# Altium schematic sheet 04-MCU.SchDoc
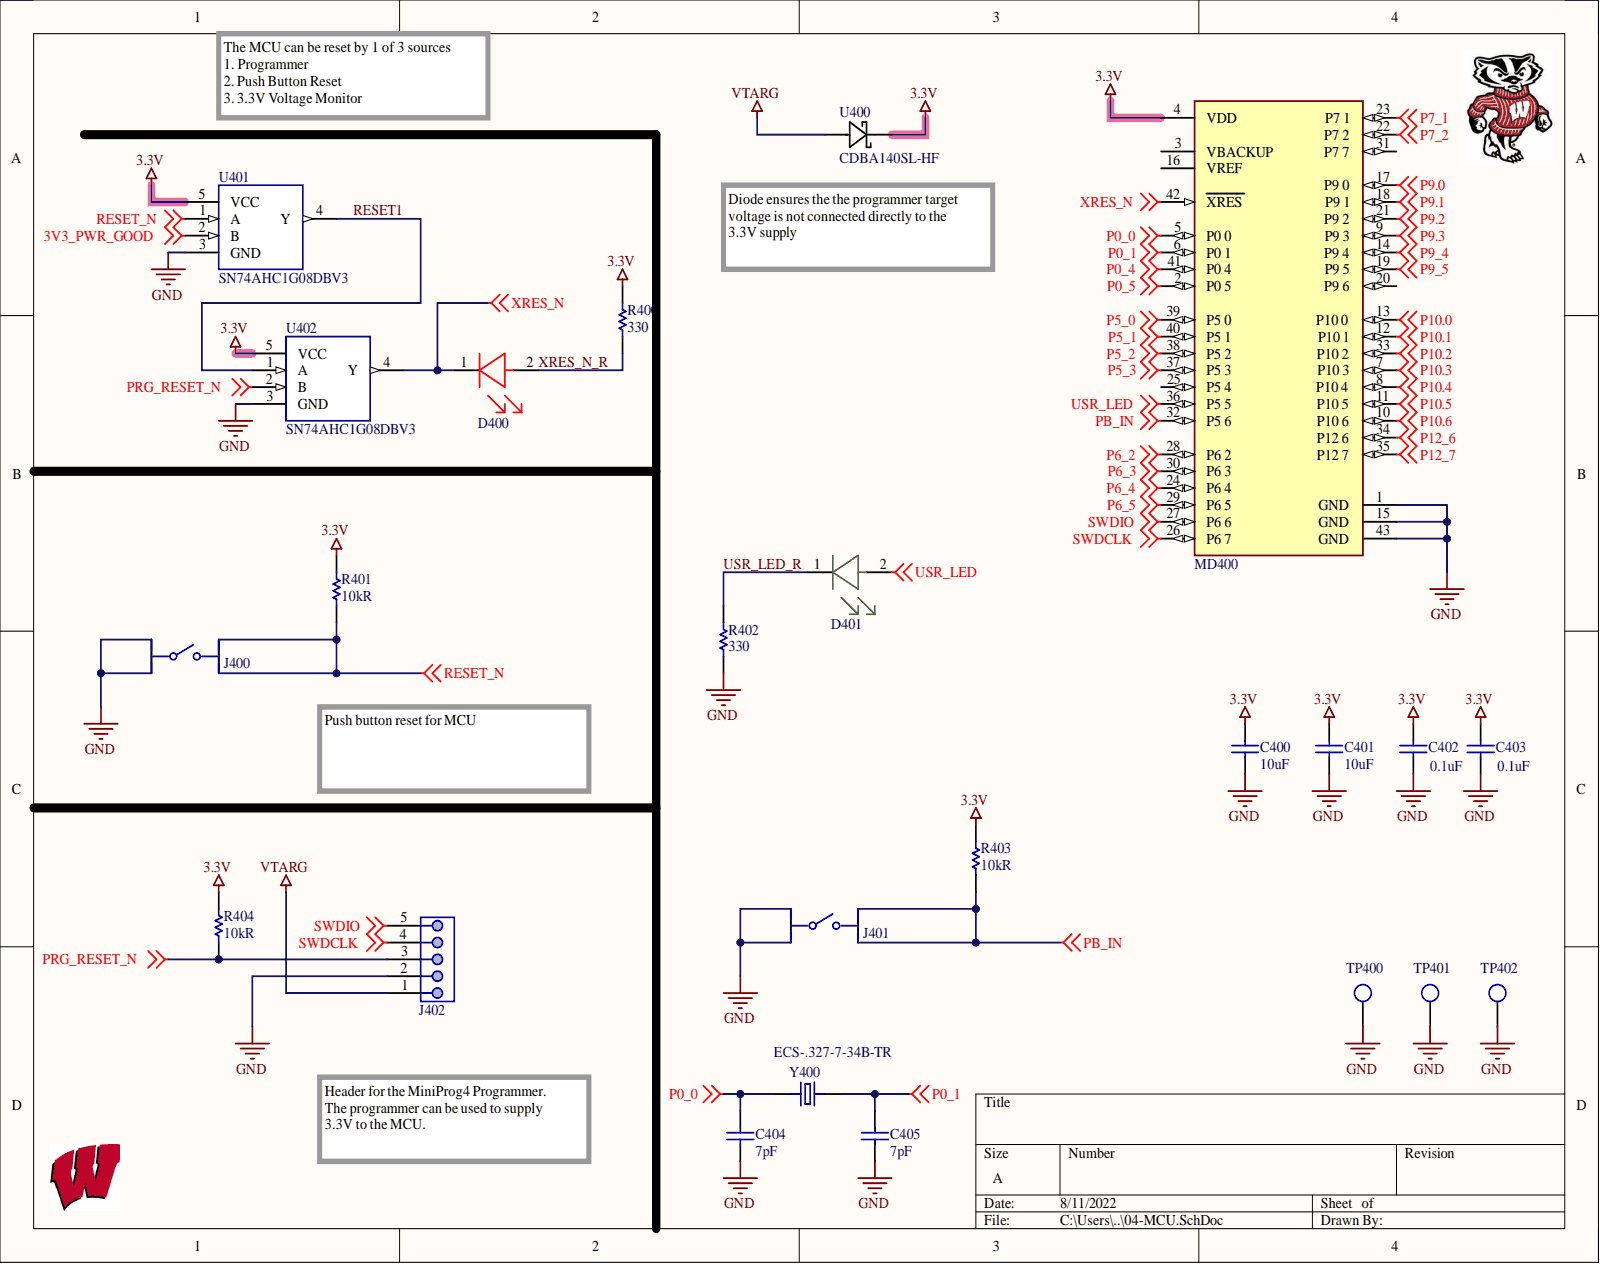
[diagram p0: 04-MCU.SchDoc]

## Parts
C400  10uF  [CAP_0805]
C401  10uF  [CAP_0805]
C402  0.1uF  [CAP_0805]
C403  0.1uF  [CAP_0805]
C404  Capacitance: 7pF  [CAP_0805]  (hidden comment: CAP_0805_P_007.00)
C405  Capacitance: 7pF  [CAP_0805]  (hidden comment: CAP_0805_P_007.00)
D400  LED_RED_0805.1  [LED_RED_0805]  (value not drawn)
D401  LED_GREEN_0805.1  [LED_GRN_0805]  (value not drawn)
J400  SPST-NO  [TE_CONNCECTIVITY_1977223-6_0]  (value not drawn)
J401  SPST-NO  [TE_CONNCECTIVITY_1977223-6_0]  (value not drawn)
J402  CONN_HEADER_MALE_1x5  [HDR1X5]  (value not drawn)
MD400  CYBLE-416045-02  [Cypress_Semiconductor_0_MFG]  (value not drawn)
R400  330  [RES_0805]
R401  Resistance: 10kR  [RES_0805]  (hidden comment: RES_0805_K_010.00)
R402  330  [RES_0805]
R403  Resistance: 10kR  [RES_0805]  (hidden comment: RES_0805_K_010.00)
R404  Resistance: 10kR  [RES_0805]  (hidden comment: RES_0805_K_010.00)
TP400  TP_1206  [TP-SMC-1206]  (value not drawn)
TP401  TP_1206  [TP-SMC-1206]  (value not drawn)
TP402  TP_1206  [TP-SMC-1206]  (value not drawn)
U400  CDBA140SL-HF  [CDBA-HF]
U401  SN74AHC1G08DBV3  [SOT23-5-AND]
U402  SN74AHC1G08DBV3  [SOT23-5-AND]
Y400  ECS-.327-7-34B-TR  [XTAL_ECS-.327-7-34B-TR]

## Nets
3.3V: C400.2 C401.2 C402.2 C403.2 MD400.4(VDD) R400.2 R401.2 R403.2 R404.2 U400.1 U401.5(VCC) U402.5(VCC)
3V3_PWR_GOOD: U401.2(B)
GND: C400.1 C401.1 C402.1 C403.1 C404.1 C405.1 J400.1 J400.2 J401.1 J401.2 J402.2 MD400.1(GND) MD400.15(GND) MD400.43(GND) R402.1 TP400.1 TP401.1 TP402.1 U401.3(GND) U402.3(GND)
P0_0: C404.2 MD400.5(P0_0) Y400.1
P0_1: C405.2 MD400.6(P0_1) Y400.2
P0_4: MD400.41(P0_4)
P0_5: MD400.2(P0_5)
P5_0: MD400.39(P5_0)
P5_1: MD400.40(P5_1)
P5_2: MD400.38(P5_2)
P5_3: MD400.37(P5_3)
P6_2: MD400.28(P6_2)
P6_3: MD400.30(P6_3)
P6_4: MD400.24(P6_4)
P6_5: MD400.29(P6_5)
P7_1: MD400.23(P7_1)
P7_2: MD400.22(P7_2)
P9.0: MD400.14(P9_4) MD400.17(P9_0) MD400.19(P9_5)
P9.1: MD400.18(P9_1)
P9.2: MD400.21(P9_2)
P9.3: MD400.9(P9_3)
P10.0: MD400.13(P10_0)
P10.1: MD400.12(P10_1)
P10.2: MD400.33(P10_2)
P10.3: MD400.7(P10_3)
P10.4: MD400.8(P10_4)
P10.5: MD400.11(P10_5)
P10.6: MD400.10(P10_6)
P12_6: MD400.34(P12_6)
P12_7: MD400.35(P12_7)
PB_IN: J401.3 J401.4 MD400.32(P5_6) R403.1
PRG_RESET_N: J402.3 R404.1 U402.2(B)
RESET_N: J400.3 J400.4 R401.1 U401.1(A)
SWDCLK: J402.4 MD400.26(P6_7)
SWDIO: J402.5 MD400.27(P6_6)
USR_LED: D401.2 MD400.36(P5_5)
VTARG: J402.1 U400.2
XRES_N: D400.1 MD400.42(XRES) U402.4(Y)
RESET1: U401.4(Y) U402.1(A)
USR_LED_R: D401.1 R402.2
XRES_N_R: D400.2 R400.1

Not connected: MD400 3(VBACKUP) 16(VREF) 20(P9_6) 25(P5_4) 31(P7_7)

## Library data of the parts
C400 C401 = CAP_0805_U_010.00: CAP CER 10UF 16V X7R 0805; Manufacturer: Samsung; Manufacturer Part Number: CL21A106KPCLQNC; Dielectric: X5R; Tolerance: 10%; Voltage Rating: 10V; Capacitance: 10uF; Case Code (Imperial): 0805; Case Code (Metric): 2012; Case/Package: 0805; Component Kind: Standard; ComponentLink1Description: https://datasheet.ciiva.com/25730/cl21a106kpclqnc-25730594.pdf; ComponentLink1URL: https://datasheet.ciiva.com/25730/cl21a106kpclqnc-25730594.pdf; ComponentLink3Description: https://datasheet.ciiva.com/26920/getdatasheetpartid-355170-26920201.pdf; ComponentLink3URL: https://datasheet.ciiva.com/26920/getdatasheetpartid-355170-26920201.pdf; Contact Plating: Tin; Depth: 1.25mm; Height: 0.85mm; Length: 2mm; Library Name: Cap_Ceramic.SchLib; Max Operating Temperature: 85°C; Min Operating Temperature: -55°C; Mount: Surface Mount; Package Quantity: 1; Packaging: Tape and Reel; Pricing 1: 1=0.22, 10=0.148, 100=0.0749, 500=0.05122, 1000=0.04531 (USD); Radiation Hardening: No; Stock 1: 40000; Supplier: Digi-Key; Supplier Part Number: 1276-2402-1-ND; Thickness: 0.037inch; Width: 0.049inch
C402 C403 = CAP_0805_U_000.10: CAP CER 0.1UF 16V 10% X7R 0805; Manufacturer 1: KEMET; Manufacturer Part Number 1: C0805C104K4RACTU; Capacitance: 100nF; Dielectric Material: Ceramic; Case Code (Imperial): 0805; Case Code (Metric): 2012; Case/Package: 0805; Component Kind: Standard; ComponentLink1Description: http://components.arrow.com/part/dataview/0323_00070420; ComponentLink1URL: http://components.arrow.com/part/dataview/0323_00070420; ComponentLink2Description: http://uk.rs-online.com/webdocs/1515/0900766b815151c5.pdf; ComponentLink2URL: http://uk.rs-online.com/webdocs/1515/0900766b815151c5.pdf; ComponentLink3Description: http://capacitoredge.kemet.com/capedge2/DataSheet?pn=C0805C104K4RACTU; ComponentLink3URL: http://capacitoredge.kemet.com/capedge2/DataSheet?pn=C0805C104K4RACTU; ComponentLink4Description: http://docs-usa.electrocomponents.com/techlib:/itc_doclist_US.htm?stockno=6480957; ComponentLink4URL: http://docs-usa.electrocomponents.com/techlib:/itc_doclist_US.htm?stockno=6480957; ComponentLink5Description: https://datasheet.ciiva.com/46443/1851736-46443710.pdf?src-supplier=Element14; ComponentLink5URL: https://datasheet.ciiva.com/46443/1851736-46443710.pdf?src-supplier=Element14; ComponentLink6Description: https://datasheet.ciiva.com/46443/1851736-46443711.pdf?src-supplier=Element14; ComponentLink6URL: https://datasheet.ciiva.com/46443/1851736-46443711.pdf?src-supplier=Element14; Contact Plating: Tin,Matte; Depth: 1.25mm; Dielectric: X7R; Height: 0.9mm; Lead Pitch: 0.75mm; Length: 2mm; Library Name: Cap_Ceramic.SchLib; Max Operating Temperature: 125°C; Min Operating Temperature: -55°C; Mount: Surface Mount,PCB; Number of Pins: 2; Package Quantity: 1; Packaging: Tape and Reel; Pricing 1: 1=0.20000, 10=0.13900, 100=0.07020, 500=0.04802, 1000=0.04248 (USD); Radiation Hardening: No; REACH SVHC: No SVHC; RoHS: Yes; Stock 1: 336262; Supplier 1: Digi-Key; Supplier Part Number 1: 399-1167-1-ND; Termination: SMD/SMT; Thickness: 0.035inch; Tolerance: 10%; Voltage: 16V; Voltage Rating: 16V; Voltage Rating (DC): 16V; Width: 0.049inch
C404 C405 = CAP_0805_P_007.00: 0805 7 pF 50 V ±0.25 pF Tolerance C0G/NP0 SMT Multilayer Ceramic Capacitor; Manufacturer 1: Kyocera AVX; Manufacturer Part Number 1: 08055A7R0CAT2A; Dielectric: C0G; Voltage Rating: 50V; Case Code (Imperial): 0805; Case Code (Metric): 2012; Case/Package: 0805; Category: Ceramic Capacitors; Component Kind: Standard; ComponentLink1Description: https://datasheet.ciiva.com/204/ccog-204944.pdf; ComponentLink1URL: https://datasheet.ciiva.com/204/ccog-204944.pdf; ComponentLink2Description: https://datasheet.ciiva.com/11570/ccog-11570969.pdf; ComponentLink2URL: https://datasheet.ciiva.com/11570/ccog-11570969.pdf; ComponentLink3Description: https://datasheet.ciiva.com/205/ccog-205398.pdf?src-supplier=Digi-Key; ComponentLink3URL: https://datasheet.ciiva.com/205/ccog-205398.pdf?src-supplier=Digi-Key; Construction: Flat; Depth: 1.25mm; Lead Free: Lead Free; Length: 2.01mm; Library Name: Cap_Ceramic.SchLib; Max Operating Temperature: 125°C; Min Operating Temperature: -55°C; Mount: Surface Mount; Package Quantity: 4000; Packaging: Tape and Reel; Pricing 1: 1=0.19000, 10=0.13500, 50=0.07680, 100=0.06360, 500=0.04462, 1000=0.03694 (USD); Radiation Hardening: No; RoHS: Yes; Series: -; Stock 1: 19240; Supplier 1: Digi-Key; Supplier Part Number 1: 478-10543-6-ND; Termination: SMD/SMT; Thickness: 0.037inch; Voltage Rating (DC): 50V; Weight: 0.000194oz; Width: 1.25mm
D400 = LED_RED_0805.1: LED SUPER RED CLR THIN 0805 SMD; Manufacturer: Vishay / Lite-On; Manufacturer Part Number: LTST-C171KRKT; Chip Material: AlGaInP; DELETED: Compliant; Dominant Wave Length: 631 nm; Forward Current: 30 mA; Forward Voltage: 2.4 V; High Brightness: Yes; Lum Intensity: 54mcd; Main Category: Chip LED; Number of  Elements: 1; Peak Wavelength: 639 nm; Power Dissipation: 75 W; Product Depth (mm): 1.25 mm; Product Height (mm): 0.8 mm; Product Length (mm): 2 mm; Rad Hardened: No; Reverse Voltage: 5 V; Shape Type: Rectangular; Test Current (It): 20 mA; Type: Uni-Color; Viewing Angle: 130 deg; Component Kind: Standard; ComponentLink1Description: https://datasheet.ciiva.com/2110/s-110-ltst-c171krkt-2110066.pdf; ComponentLink1URL: https://datasheet.ciiva.com/2110/s-110-ltst-c171krkt-2110066.pdf; ComponentLink2Description: https://datasheet.ciiva.com/2110/s-110-ltst-c171krkt-2110067.pdf; ComponentLink2URL: https://datasheet.ciiva.com/2110/s-110-ltst-c171krkt-2110067.pdf; ComponentLink3Description: https://datasheet.ciiva.com/2110/s-110-ltst-c171krkt-2110068.pdf; ComponentLink3URL: https://datasheet.ciiva.com/2110/s-110-ltst-c171krkt-2110068.pdf; ComponentLink4Description: https://datasheet.ciiva.com/12403/lite-on-ltst-c171krkt-187201-12403781.pdf; ComponentLink4URL: https://datasheet.ciiva.com/12403/lite-on-ltst-c171krkt-187201-12403781.pdf; ComponentLink5Description: https://datasheet.ciiva.com/11775/0900766b80dc0299-11775643.pdf; ComponentLink5URL: https://datasheet.ciiva.com/11775/0900766b80dc0299-11775643.pdf; ComponentLink6Description: https://datasheet.ciiva.com/16444/lite-on20ltst-c171krkt-16444779.pdf; ComponentLink6URL: https://datasheet.ciiva.com/16444/lite-on20ltst-c171krkt-16444779.pdf; Emitting Color: Red; Lens Dimensions: 1.4x1.25x0.5 mm; Library Name: LEDs.SchLib; Luminous Intensity: 54mcd; Mounting: Surface Mount; Operating Temp Range: -55C to 85C; Operating Temperature Classification: Industrial; Package Type: Chip Led; Packaging: Tape and Reel; Pin Count: 2; Pricing 1: 1=0.31, 10=0.225, 25=0.1656, 100=0.1183, 250=0.0804, 500=0.071, 1000=0.0556 (USD); Stock 1: 97347; Supplier 1: Digikey; Supplier Part Number 1: 160-1427-1-ND
D401 = LED_GREEN_0805.1: LED GREEN CLEAR THIN 0805 SMD; Manufacturer 1: Vishay / Lite-On; Manufacturer Part Number 1: LTST-C171KGKT; Chip Material: AlGaInP; DELETED: Compliant; Dominant Wave Length: 571 nm; Emitting Color: Yellow Green; Forward Current: 30 mA; Forward Voltage: 2.4 V; High Brightness: Yes; Lum Intensity: 35mcd; Main Category: Chip LED; Number of  Elements: 1; Peak Wavelength: 574 nm; Power Dissipation: 75 W; Product Depth (mm): 1.25 mm; Product Height (mm): 0.8 mm; Product Length (mm): 2 mm; Rad Hardened: No; Reverse Voltage: 5 V; Shape Type: Rectangular; Test Current (It): 20 mA; Type: Uni-Color; Viewing Angle: 130 deg; Component Kind: Standard; ComponentLink1Description: https://datasheet.ciiva.com/15118/getdatasheetpartid-214542-15118099.pdf; ComponentLink1URL: https://datasheet.ciiva.com/15118/getdatasheetpartid-214542-15118099.pdf; ComponentLink2Description: https://datasheet.ciiva.com/16200/lite-on20ltst-c171kgkt-16200100.pdf; ComponentLink2URL: https://datasheet.ciiva.com/16200/lite-on20ltst-c171kgkt-16200100.pdf; ComponentLink3Description: https://datasheet.ciiva.com/2110/s-110-ltst-c171kgkt-2110072.pdf; ComponentLink3URL: https://datasheet.ciiva.com/2110/s-110-ltst-c171kgkt-2110072.pdf; ComponentLink4Description: https://datasheet.ciiva.com/2110/s-110-ltst-c171kgkt-2110073.pdf; ComponentLink4URL: https://datasheet.ciiva.com/2110/s-110-ltst-c171kgkt-2110073.pdf; ComponentLink5Description: https://datasheet.ciiva.com/11774/0900766b80dc0298-11774377.pdf; ComponentLink5URL: https://datasheet.ciiva.com/11774/0900766b80dc0298-11774377.pdf; ComponentLink6Description: https://datasheet.ciiva.com/2110/s-110-ltst-c171kgkt-2110074.pdf; ComponentLink6URL: https://datasheet.ciiva.com/2110/s-110-ltst-c171kgkt-2110074.pdf; ComponentLink7Description: https://datasheet.ciiva.com/12400/lite-on-ltst-c171kgkt-187692-12400799.pdf; ComponentLink7URL: https://datasheet.ciiva.com/12400/lite-on-ltst-c171kgkt-187692-12400799.pdf; Lens Dimensions: 1.4x1.25x0.5 mm; Library Name: LEDs.SchLib; Luminous Intensity: 35mcd; Mounting: Surface Mount; Operating Temp Range: -55C to 85C; Operating Temperature Classification: Industrial; Package Type: Chip Led; Packaging: Tape and Reel; Pin Count: 2; Pricing 1: 1=0.31, 10=0.225, 25=0.1656, 100=0.1183, 250=0.0804, 500=0.071, 1000=0.0556 (USD); Stock 1: 322411; Supplier 1: Digi-Key; Supplier Part Number 1: 160-1426-1-ND
J400 J401 = SPST-NO: CONN SWITCH TACTILE SPST-NO 0.05A 24V; Manufacturer: TE CONNCECTIVITY; Part Number: 1977223-6; Component Kind: Standard; ComponentLink1Description: Upverter; ComponentLink1URL: https://upverter.com/upn/revision/93322581e4cbca7c/; ComponentLink2Description: Datasheet; ComponentLink2URL: http://www.mouser.com/ds/2/418/NG_CD_1977223_A4-679782.pdf; Digikey Description: CONN SWITCH TACTILE SPST-NO 0.05A 24V; Digikey Part Number: 450-2054-1-ND; helpURL: https://upverter.com/upn/revision/93322581e4cbca7c/; Lead Free: yes; Library Name: Switches.SchLib; Mouser Part Number: 506-1977223-6; Package: SW_TACT; RoHS: TRUE; Supplier 1: Digi-Key; Supplier 2: Mouser; Supplier Part Number 1: 450-2054-1-ND; Supplier Part Number 2: 506-1977223-6; Temperature: -40°C to +105°C; Temperature Range High: +105°C; Temperature Range Low: -40°C
J402 = CONN_HEADER_MALE_1x5: Header, 5-Pin; Manufacturer 1: Sullins; Manufacturer Part Number 1: PREC005SACN-RC; Connector Type: Male Pin; Number of Positions: 5; Pitch: 0.1inch; Color: Black; Component Kind: Standard; ComponentLink1Description: http://www.farnell.com/cad/1737268.pdf; ComponentLink1URL: http://www.farnell.com/cad/1737268.pdf; ComponentLink2Description: http://katalog.we-online.de/em/datasheet/6130xx11121.pdf; ComponentLink2URL: http://katalog.we-online.de/em/datasheet/6130xx11121.pdf; ComponentLink3Description: https://datasheet.ciiva.com/741/xrxczzzsxxn-rc-st-11635-b-741840.pdf?src-supplier=Digi-Key; ComponentLink3URL: https://datasheet.ciiva.com/741/xrxczzzsxxn-rc-st-11635-b-741840.pdf?src-supplier=Digi-Key; Contact Mating Length: 0.23inch; Contact Plating: Tin; Library Name: Connectors.SchLib; Mount: Through Hole; Number of Rows: 1; Package Quantity: 1; Packaging: Bulk; Pricing 1: 1=0.19000, 10=0.17400, 25=0.12960, 50=0.11120, 100=0.10740, 250=0.09264, 500=0.08892 (USD); RoHS: Yes; Stock 1: 0; Supplier 1: Digi-Key; Supplier Part Number 1: S1032EC-05-ND; Termination: Solder
MD400 = CYBLE-416045-02: Bluetooth v5.0 Transceiver Module 2.4GHz ~ 2.5GHz Integrated, Trace Surface Mount; Component Kind: Standard; Library Name: ICs.SchLib; Supplier 1: Digi-Key; Supplier Part Number 1: 428-4622-1-ND
R400 R402 = RES_0805_R_330.00: RES 330 OHM 1% 1/8W 0805; Manufacturer 1: Stackpole Electronics; Manufacturer Part Number 1: RMCF0805FT330R; Max Power Dissipation: 125mW; Resistance: 330R; Temperature Coefficient: 100ppm/°C; Case Code (Imperial): 0805; Case Code (Metric): 2012; Case/Package: 0805; Category: Chip SMD Resistors; Component Kind: Standard; ComponentLink1Description: http://components.arrow.com/part/dataview/40963487S9848862N1174?src-supplier=Arrow+Electronics; ComponentLink1URL: http://components.arrow.com/part/dataview/40963487S9848862N1174?src-supplier=Arrow+Electronics; ComponentLink2Description: https://www.seielect.com/catalog/SEI-RMCF_RMCP.pdf?src-supplier=Avnet; ComponentLink2URL: https://www.seielect.com/catalog/SEI-RMCF_RMCP.pdf?src-supplier=Avnet; ComponentLink3Description: https://www.seielect.com/Catalog/SEI-RMCF_RMCP.pdf?src-supplier=Digi-Key; ComponentLink3URL: https://www.seielect.com/Catalog/SEI-RMCF_RMCP.pdf?src-supplier=Digi-Key; ComponentLink4Description: https://www.seielect.com/catalog/SEI-Packaging.pdf?src-supplier=Digi-Key; ComponentLink4URL: https://www.seielect.com/catalog/SEI-Packaging.pdf?src-supplier=Digi-Key; ComponentLink5Description: https://www.verical.com/server-webapp/html/getDatasheet?partID=559884&src-supplier=Verical; ComponentLink5URL: https://www.verical.com/server-webapp/html/getDatasheet?partID=559884&src-supplier=Verical; Construction: Rectangular; Contact Plating: Tin,Matte; Depth: 1.25mm; Features: Automotive AEC-Q200; Height: 0.026inch; Lead Free: Lead Free; Length: 0.079inch; Library Name: Resistors.SchLib; Max Operating Temperature: 155°C; Military Standard: Not; Min Operating Temperature: -55°C; Mount: Surface Mount; Number of Terminations: 2; Packaging: Tape and Reel; Pricing 1: 1=0.10000, 10=0.02700, 100=0.01090, 1000=0.00488, 2500=0.00423 (USD); Radiation Hardening: No; Resistor Type: Thick Film; RoHS: Yes; RoHS Compliant: Yes; Series: RMCF; Stock 1: 205996; Supplier 1: Digi-Key; Supplier Part Number 1: RMCF0805FT330RCT-ND; Tolerance: 1%; Width: 0.049inch
R401 R403 R404 = RES_0805_K_010.00: RES SMD 10K OHM 1% 1/8W 0805; Manufacturer 1: Yageo; Manufacturer Part Number 1: RC0805FR-0710KL; Max Power Dissipation: 125mW; Temperature Coefficient: 100ppm/°C; Voltage Rating: 150V; Case Code (Imperial): 0805; Case Code (Metric): 2012; Case/Package: 0805; Category: Chip SMD Resistors; Component Kind: Standard; ComponentLink1Description: https://datasheet.ciiva.com/11808/0900766b8106beab-11808151.pdf?src-supplier=RSComponents; ComponentLink1URL: https://datasheet.ciiva.com/11808/0900766b8106beab-11808151.pdf?src-supplier=RSComponents; ComponentLink2Description: https://datasheet.ciiva.com/12669/rc0805-12669688.pdf?src-supplier=Mouser; ComponentLink2URL: https://datasheet.ciiva.com/12669/rc0805-12669688.pdf?src-supplier=Mouser; ComponentLink3Description: https://datasheet.ciiva.com/46447/444164-46447563.pdf?src-supplier=Element14; ComponentLink3URL: https://datasheet.ciiva.com/46447/444164-46447563.pdf?src-supplier=Element14; ComponentLink4Description: https://datasheet.ciiva.com/2456/pyu-r-int-thick-7-2456972.pdf?src-supplier=Digi-Key; ComponentLink4URL: https://datasheet.ciiva.com/2456/pyu-r-int-thick-7-2456972.pdf?src-supplier=Digi-Key; ComponentLink5Description: https://datasheet.ciiva.com/2456/pyu-r-int-thick-7-2456973.pdf?src-supplier=Digi-Key; ComponentLink5URL: https://datasheet.ciiva.com/2456/pyu-r-int-thick-7-2456973.pdf?src-supplier=Digi-Key; ComponentLink6Description: https://datasheet.ciiva.com/2456/pyu-r-int-thick-7-2456974.pdf?src-supplier=Digi-Key; ComponentLink6URL: https://datasheet.ciiva.com/2456/pyu-r-int-thick-7-2456974.pdf?src-supplier=Digi-Key; ComponentLink7Description: https://datasheet.ciiva.com/16747/rc0805-16747087.pdf?src-supplier=Future+Electronics; ComponentLink7URL: https://datasheet.ciiva.com/16747/rc0805-16747087.pdf?src-supplier=Future+Electronics; Construction: Rectangular; Depth: 1.25mm; Features: Moisture Resistant; Height: 0.024inch; Lead Free: Lead Free; Length: 2mm; Library Name: Resistors.SchLib; Max Operating Temperature: 155°C; Military Standard: Not; Min Operating Temperature: -55°C; Number of Terminations: 2; Package Quantity: 1; Packaging: Tape and Reel; Power Rating: 125mW; Pricing 1: 1=0.10000, 10=0.04200, 100=0.01690, 1000=0.00759, 2500=0.00659 (USD); REACH SVHC: Unknown; Resistance Tolerance: 1%; Resistor Type: Thick Film; RoHS: Yes; RoHS Compliant: Yes; Series: RC; Size Code: 0805; Stock 1: 7562122; Supplier 1: Digi-Key; Supplier Part Number 1: 311-10.0KCRCT-ND; Technology: THICK FILM; Termination: SMD/SMT; Tolerance: 1%; Width: 0.049inch
TP400-TP402 = TP_1206: Test Point SMD 1206; Manufacturer: TE Connectivity (Tyco Electronics); Manufacturer Part Number: 1625854-1; Power Rating: N/R; Resistance Value: 0 Ohm; Case Size: 1206; Component Kind: Standard; ComponentLink1Description: https://datasheet.ciiva.com/6439/eng-ds-1773266-a-6439169.pdf; ComponentLink1URL: https://datasheet.ciiva.com/6439/eng-ds-1773266-a-6439169.pdf; ComponentLink2Description: https://datasheet.ciiva.com/30136/1773266-a-30136341.pdf; ComponentLink2URL: https://datasheet.ciiva.com/30136/1773266-a-30136341.pdf; ComponentLink3Description: https://datasheet.ciiva.com/6439/eng-ds-1773266-a-6439080.pdf; ComponentLink3URL: https://datasheet.ciiva.com/6439/eng-ds-1773266-a-6439080.pdf; ComponentLink4Description: https://datasheet.ciiva.com/6439/eng-ds-1773266-a-6439081.pdf; ComponentLink4URL: https://datasheet.ciiva.com/6439/eng-ds-1773266-a-6439081.pdf; ComponentLink5Description: https://datasheet.ciiva.com/6439/eng-ds-1773266-a-6439083.pdf; ComponentLink5URL: https://datasheet.ciiva.com/6439/eng-ds-1773266-a-6439083.pdf; Dimension: 3.2 x 1.6 x 1.25mm; Family: RC; Library Name: Mechanical.SchLib; Mounting: Surface Mount; Operating Temperature: -55 to 125 C; Packaging: Tape and Reel; Part No: 1625854-1; Pricing 1: 1=0.28, 10=0.261, 25=0.224, 50=0.1902, 100=0.1828, 250=0.1641, 500=0.1567, 1000=0.1306 (USD); RoHS: Yes; Stock 1: 31937; Supplier: Digi-Key; Supplier Part Number: A106143CT-ND; Temperature Coefficient: N/R; Terminal Pitch: N/R; Termination Style: N/R; Tolerance: N/R
U400 = CDBA140SL-HF: Rectifier Diode Schottky 40V 1A 2-Pin SMA T/R; Manufacturer 1: Comchip; Average Rectified Current: 1.4A; Max Surge Current: 30A; Case/Package: DO-214AC; Category: Rectifier Diodes; Component Kind: Standard; ComponentLink1Description: https://datasheet.ciiva.com/6377/cdba120sl-hfthru295959-cdba140sl-hfreva-6377798.pdf?src-supplier=Digi-Key; ComponentLink1URL: https://datasheet.ciiva.com/6377/cdba120sl-hfthru295959-cdba140sl-hfreva-6377798.pdf?src-supplier=Digi-Key; Digi-Key_Part_Number_1: 641-1762-1-ND; Digi-Key_Part_Number_2: 641-1762-2-ND; Digi-Key_Part_Number_3: 641-1762-2-NDL; Digi-Key_Part_Number_4: 641-1762-6-ND; Digi-Key_Part_Number_5: CDBA140SL-HF-ND; Library Name: Diodes.SchLib; Manufacturer Part Number 1: CDBA140SL-HF; Manufacturer_Part_Number: CDBA140SL-HF; Max Reverse Voltage (DC): 40V; Mfr_Name: Comchip; Mount: Surface Mount; Package Quantity: 5000; Packaging: Tape and Reel; Pricing 1: 1=0.60000, 10=0.52400, 100=0.40140, 500=0.31734, 1000=0.25387, 2000=0.23007 (USD); RefDes: RefDes; RoHS: Yes; RoHS Compliant: Yes; Series: -; Stock 1: 277936; Supplier 1: Digi-Key; Supplier Part Number 1: 641-1762-1-ND; Type: DEV; Copyright: Copyright (C) 2022 Ultra Librarian. All rights reserved.
U401 U402 = SN74AHC1G08DBV3: AND Gate 1-Element 2-IN CMOS 5-Pin SOT-23 T/R; Manufacturer 1: Texas Instruments; Manufacturer Part Number 1: SN74AUP1G08DBVR; High Level Output Current: -4mA; Input Type: SCHMITT TRIGGER; Low Level Output Current: 4mA; Max Supply Voltage: 3.6V; Min Supply Voltage: 800mV; Number of Bits: 1; Number of Input Lines: 2; Propagation Delay: 6.2ns; Quiescent Current: 500nA; Turn-On Delay Time: 20.9ns; Case/Package: SOT-23; Category: Logic Gates; Component Kind: Standard; ComponentLink1Description: https://datasheet.ciiva.com/7122/1857511-7122245.pdf?src-supplier=Newark; ComponentLink1URL: https://datasheet.ciiva.com/7122/1857511-7122245.pdf?src-supplier=Newark; ComponentLink2Description: https://datasheet.ciiva.com/1864/sn74aup1g08-1864690.pdf?src-supplier=Digi-Key; ComponentLink2URL: https://datasheet.ciiva.com/1864/sn74aup1g08-1864690.pdf?src-supplier=Digi-Key; ComponentLink3Description: https://datasheet.ciiva.com/1864/sn74aup1g08-1864689.pdf?src-supplier=Digi-Key; ComponentLink3URL: https://datasheet.ciiva.com/1864/sn74aup1g08-1864689.pdf?src-supplier=Digi-Key; ComponentLink4Description: https://datasheet.ciiva.com/1864/sn74aup1g08-1864691.pdf?src-supplier=Digi-Key; ComponentLink4URL: https://datasheet.ciiva.com/1864/sn74aup1g08-1864691.pdf?src-supplier=Digi-Key; ComponentLink5Description: https://datasheet.ciiva.com/14291/sn74aup1g08-14291291.pdf?src-supplier=Avnet; ComponentLink5URL: https://datasheet.ciiva.com/14291/sn74aup1g08-14291291.pdf?src-supplier=Avnet; ComponentLink6Description: https://datasheet.ciiva.com/14291/sn74aup1g08-14291295.pdf?src-supplier=Avnet; ComponentLink6URL: https://datasheet.ciiva.com/14291/sn74aup1g08-14291295.pdf?src-supplier=Avnet; Contact Plating: Tin,Matte; Lead Free: Lead Free; Library Name: ICs.SchLib; Logic Function: AND GATE; Max Operating Temperature: 85°C; Min Operating Temperature: -40°C; Mount: Surface Mount; Number of Circuits: 1; Number of Elements: 1; Number of Gates: 1; Number of Inputs: 2; Number of Output Lines: 1; Number of Outputs: 1; Number of Pins: 5; Output Current: 4mA; Package Quantity: 3000; Packaging: Tape and Reel; Pricing 1: 1=0.49000, 10=0.39400, 25=0.36000, 100=0.26800, 250=0.24284, 500=0.20098, 1000=0.15073 (USD); Radiation Hardening: No; REACH SVHC: No SVHC; RoHS: Yes; RoHS Compliant: Yes; Series: 74AUP; Stock 1: 21212; Supplier 1: Digi-Key; Supplier Part Number 1: 296-SN74AUP1G08DBVRCT-ND; Technology: CMOS; Weight: 0.000395oz
Y400 = ECS-.327-7-34B-TR: Crystal 0.032768MHz ±20ppm (Tol) 7pF Flexural 70000Ohm 2-Pin Ultra Mini-CSMD T/R; Manufacturer 1: ECS International; ESR (Equivalent Series Resistance): 70kR; Frequency: 32.768kHz; MF: ECS Inc.; Availability: Unavailable; Case/Package: SMD/SMT; Category: Crystals; Component Kind: Standard; ComponentLink1Description: https://datasheet.ciiva.com/852/ecx-31b-852944.pdf?src-supplier=Digi-Key; ComponentLink1URL: https://datasheet.ciiva.com/852/ecx-31b-852944.pdf?src-supplier=Digi-Key; ComponentLink2Description: https://datasheet.ciiva.com/852/ecx-31b-852943.pdf?src-supplier=Digi-Key; ComponentLink2URL: https://datasheet.ciiva.com/852/ecx-31b-852943.pdf?src-supplier=Digi-Key; ComponentLink3Description: https://datasheet.ciiva.com/852/ecx-31b-852942.pdf?src-supplier=Digi-Key; ComponentLink3URL: https://datasheet.ciiva.com/852/ecx-31b-852942.pdf?src-supplier=Digi-Key; Frequency Tolerance: 20ppm; Height: 0.035inch; Lead Free: Lead Free; Length: 0.126inch; Library Name: Crystals.SchLib; Load Capacitance: 7pF; Manufacturer Part Number 1: ECS-.327-7-34B-TR; Max Operating Temperature: 85°C; Min Operating Temperature: -40°C; Mount: Surface Mount; MP: ECS-.327-7-34B-TR; Number of Pins: 2; Package: SMD-2 ECS International; Package Quantity: 1; Packaging: Tape and Reel; Price: None; Pricing 1: 1=0.80000, 10=0.70800, 50=0.66220, 100=0.58520, 500=0.55440, 1000=0.46200 (USD); RoHS: Yes; RoHS Compliant: Yes; Series: ECX-31B; SnapEDA_Link: https://www.snapeda.com/parts/ECS-.327-7-34B-TR/ECS%20Inc./view-part/55054/?ref=snap; Stock 1: 428775; Supplier 1: Digi-Key; Supplier Part Number 1: XC1656CT-ND; Termination: SMD/SMT; Tolerance: 20ppm; Width: 0.059inch
